# Altium schematic sheet bph.SchDoc
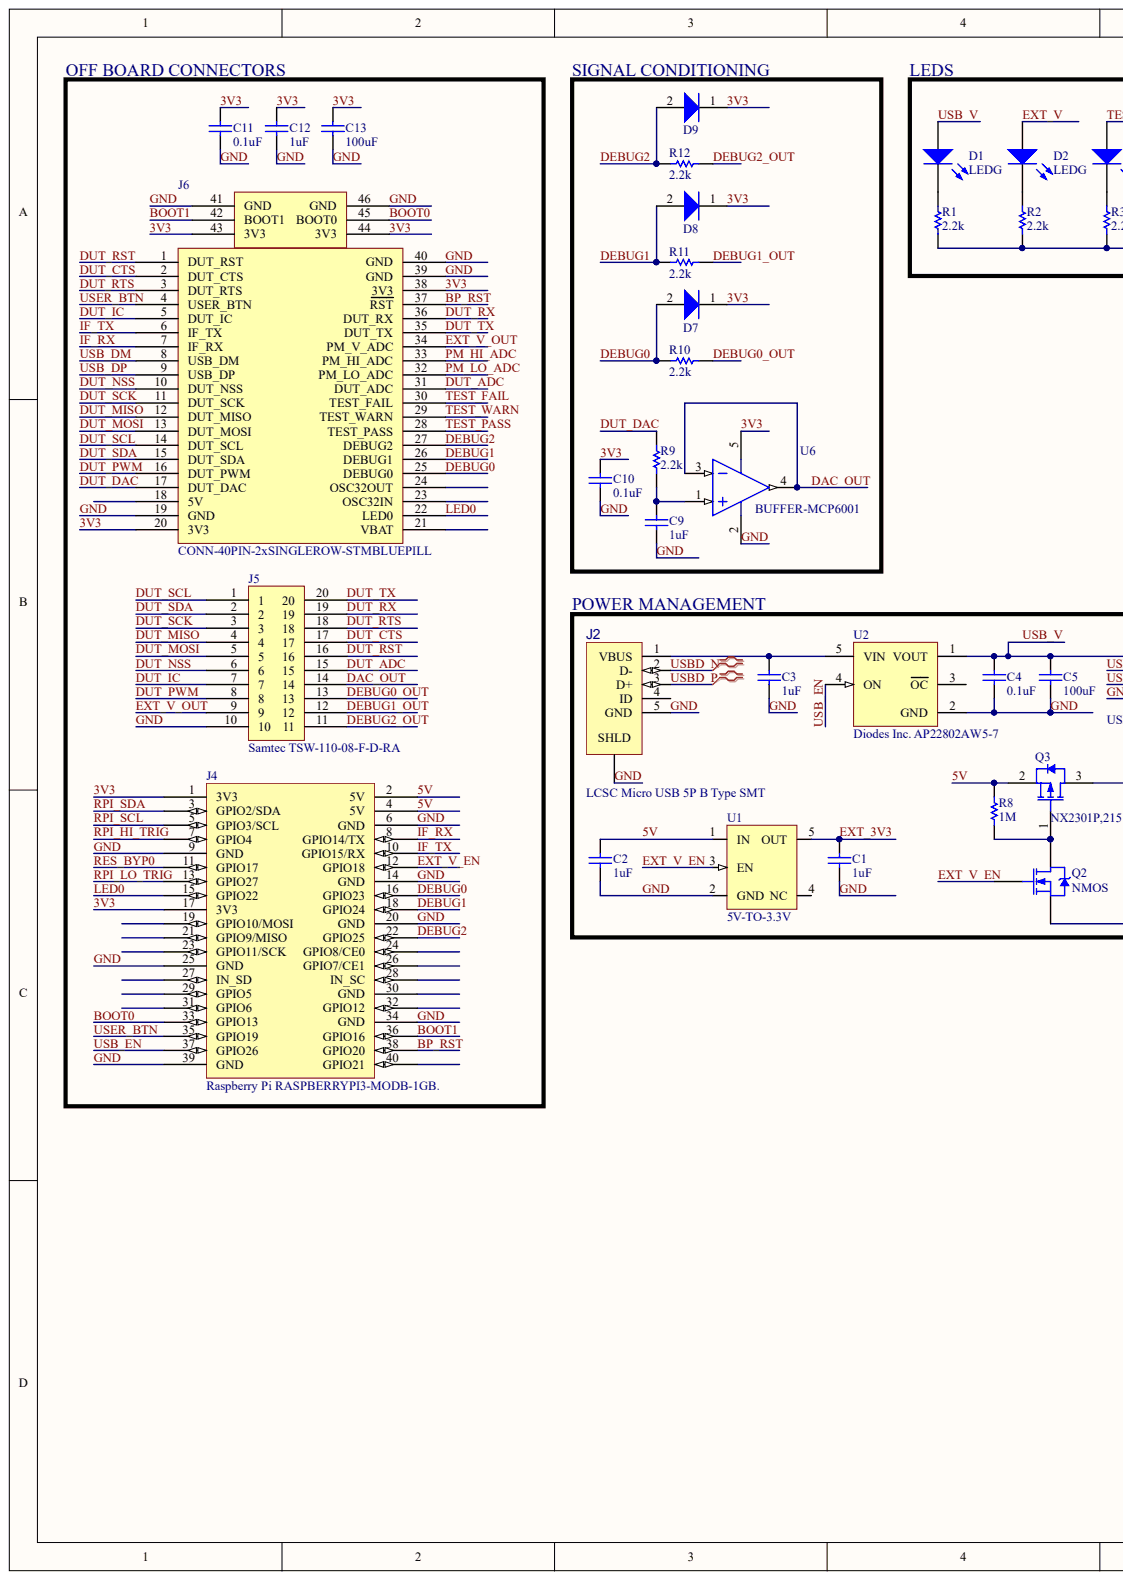
[diagram p0: bph.SchDoc, left]
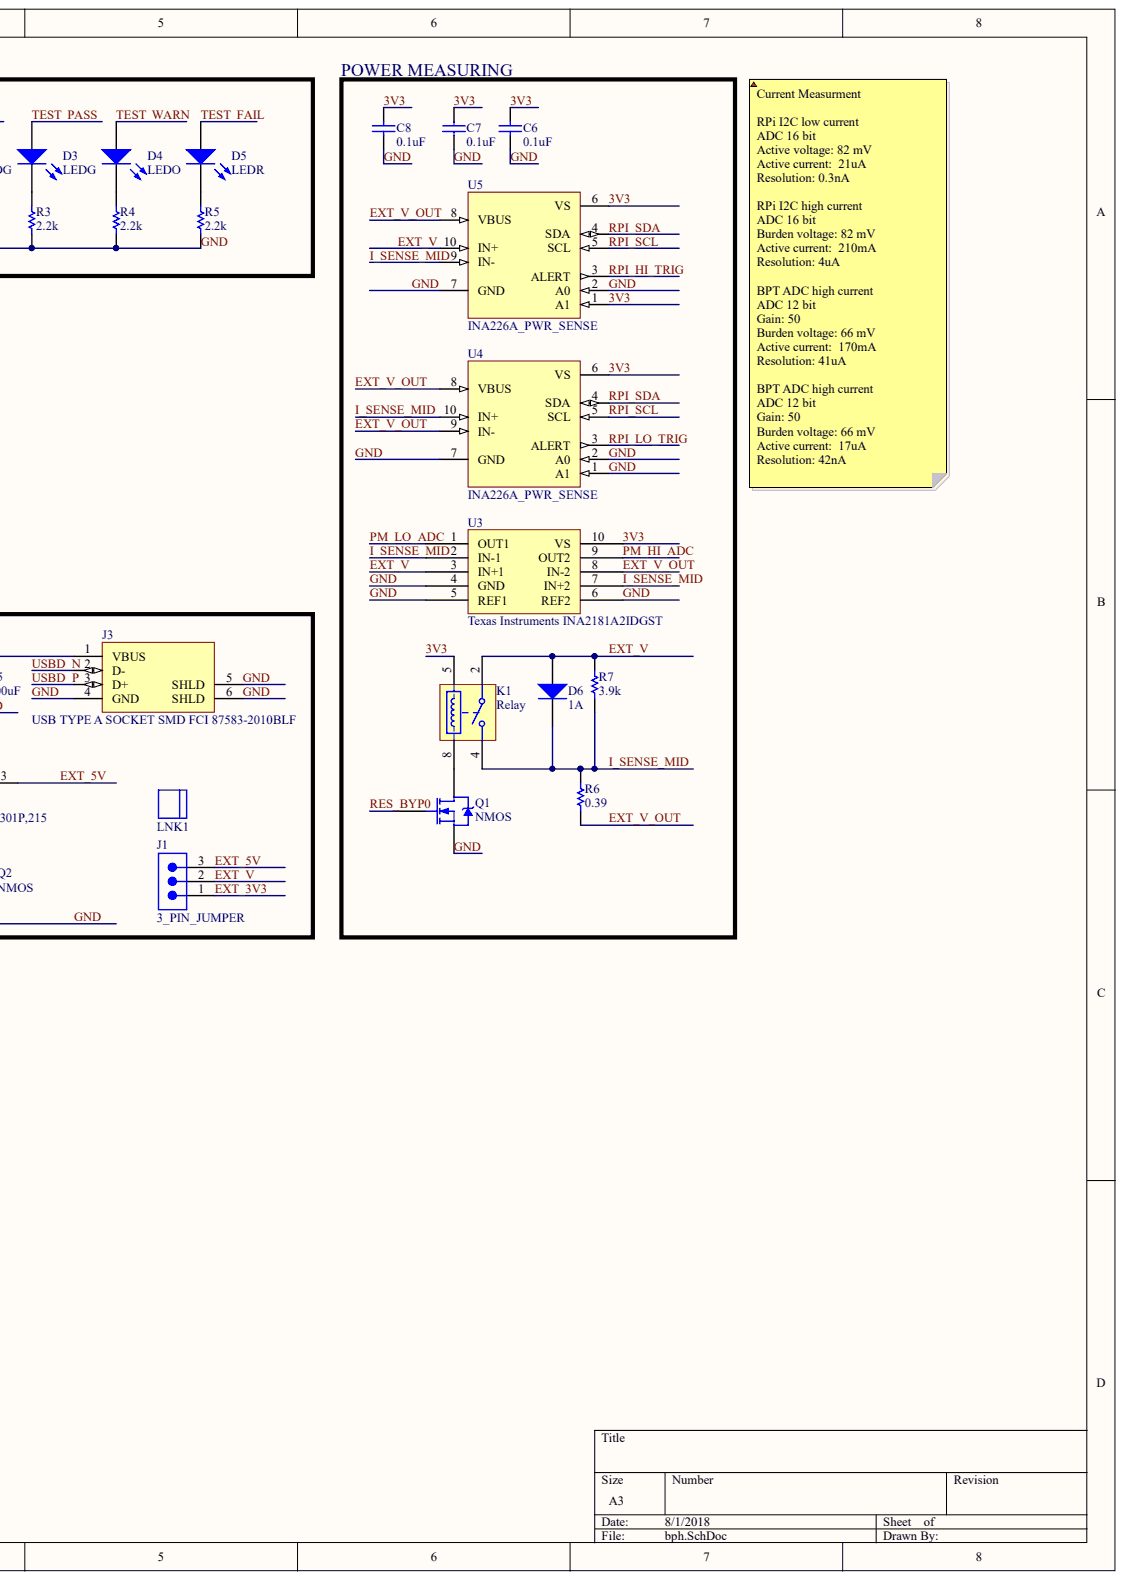
[diagram p1: bph.SchDoc, right]

## Parts
C1  1uF  [CL10B105MO8NNWC]
C2  1uF  [CL10B105MO8NNWC]
C3  1uF  [CL10B105MO8NNWC]
C4  0.1uF  [PCB-vacqisieyscyt9e4k3s1-1]
C5  100uF  [CAPC3225X280X60ML40T30]
C6  0.1uF  [PCB-vacqisieyscyt9e4k3s1-1]
C7  0.1uF  [PCB-vacqisieyscyt9e4k3s1-1]
C8  0.1uF  [PCB-vacqisieyscyt9e4k3s1-1]
C9  1uF  [CL10B105MO8NNWC]
C10  0.1uF  [PCB-vacqisieyscyt9e4k3s1-1]
C11  0.1uF  [PCB-vacqisieyscyt9e4k3s1-1]
C12  1uF  [CL10B105MO8NNWC]
C13  100uF  [CAPC3225X280X60ML40T30]
D1  LEDG  [DIOM1608X06N]
D2  LEDG  [DIOM1608X06N]
D3  LEDG  [DIOM1608X06N]
D4  LEDO  [PCB-myqng3jjgetfjfhlr5is-1]
D5  LEDR  [DIOM1608X06N]
D6  1A  [DO-214AA]
D7  NSR0140P2T5G  [ONSC-SOD-923-2-514AA-01_D_V]  (value not drawn)
D8  NSR0140P2T5G  [ONSC-SOD-923-2-514AA-01_D_V]  (value not drawn)
D9  NSR0140P2T5G  [ONSC-SOD-923-2-514AA-01_D_V]  (value not drawn)
J1  3_PIN_JUMPER  [PCB-tlokwgpvaxmsx1zr2ln6-1]
J2  LCSC Micro USB 5P B Type SMT  [PCB-edlm2od7brxgpj78b9fm-1]
J3  USB TYPE A SOCKET SMD FCI 87583-2010BLF  Manufacturer Part Number: 87583-2010BLF  [PCB-777755-1]
J4  Raspberry Pi RASPBERRYPI3-MODB-1GB.  [PCB-azd924abkl4b1ygn5hl9-1]
J5  Samtec TSW-110-08-F-D-RA  Manufacturer Part Number: TSW-110-08-F-D-RA  [DIP20]
J6  CONN-40PIN-2xSINGLEROW-STMBLUEPILL  [BLUEPILLHEADER]
K1  Relay  [PCB-q1rlmdefxni9l25t7dtb-1]
LNK1  Sullins QPC02SXGN-RC  (value not drawn)  (mechanical)
Q1  NMOS  [PCB-nw73lpgy3xhhfuwv1f85-1]
Q2  NMOS  [PCB-nw73lpgy3xhhfuwv1f85-1]
Q3  NX2301P,215  [NXP-SOT23_N]
R1  2.2k  [RESC1608X55X30LL15T15]
R2  2.2k  [RESC1608X55X30LL15T15]
R3  2.2k  [RESC1608X55X30LL15T15]
R4  2.2k  [RESC1608X55X30LL15T15]
R5  2.2k  [RESC1608X55X30LL15T15]
R6  0.39  [RESC3126X65X50ML10T20]
R7  3.9k  [RESC3225X70X50NL20T20]
R8  1M  [RESC1608X55X30LL15T15]
R9  2.2k  [RESC1608X55X30LL15T15]
R10  2.2k  [RESC1608X55X30LL15T15]
R11  2.2k  [RESC1608X55X30LL15T15]
R12  2.2k  [RESC1608X55X30LL15T15]
U1  5V-TO-3.3V  [SOT23-5_D]
U2  Diodes Inc. AP22802AW5-7  Manufacturer Part Number: AP22802AW5-7  [SOT-23-5]
U3  Texas Instruments INA2181A2IDGST  Manufacturer Part Number: INA2181A2IDGST  [SOP10]
U4  INA226A_PWR_SENSE  [PCB-TSSOP10-BoxOverlay]
U5  INA226A_PWR_SENSE  [PCB-TSSOP10-BoxOverlay]
U6  BUFFER-MCP6001  [SOT-23-OT5_N]

## Nets
3V3: C6.2 C7.2 C8.2 C10.2 C11.2 C12.2 C13.2 D7.1 D8.1 D9.1 J4.1(3V3) J4.17(3V3) J6.20(3V3) J6.38(3V3) J6.43(3V3) J6.44(3V3) K1.5 U3.10(VS) U4.6(VS) U5.1(A1) U5.6(VS) U6.5
5V: C2.2 J4.2(5V) J4.4(5V) Q3.2 R8.2 U1.1(IN)
BOOT0: J4.33(GPIO13) J6.45(BOOT0)
BOOT1: J4.36(GPIO16) J6.42(BOOT1)
BP_RST: J4.38(GPIO20) J6.37(RST)
DAC_OUT: J5.14 U6.3 U6.4
DEBUG0: D7.2 J4.16(GPIO23) J6.25(DEBUG0) R10.2
DEBUG0_OUT: J5.13 R10.1
DEBUG1: D8.2 J4.18(GPIO24) J6.26(DEBUG1) R11.2
DEBUG1_OUT: J5.12 R11.1
DEBUG2: D9.2 J4.22(GPIO25) J6.27(DEBUG2) R12.2
DEBUG2_OUT: J5.11 R12.1
DUT_ADC: J5.15 J6.31(DUT_ADC)
DUT_CTS: J5.17 J6.2(DUT_CTS)
DUT_DAC: J6.17(DUT_DAC) R9.2
DUT_IC: J5.7 J6.5(DUT_IC)
DUT_MISO: J5.4 J6.12(DUT_MISO)
DUT_MOSI: J5.5 J6.13(DUT_MOSI)
DUT_NSS: J5.6 J6.10(DUT_NSS)
DUT_PWM: J5.8 J6.16(DUT_PWM)
DUT_RST: J5.16 J6.1(DUT_RST)
DUT_RTS: J5.18 J6.3(DUT_RTS)
DUT_RX: J5.19 J6.36(DUT_RX)
DUT_SCK: J5.3 J6.11(DUT_SCK)
DUT_SCL: J5.1 J6.14(DUT_SCL)
DUT_SDA: J5.2 J6.15(DUT_SDA)
DUT_TX: J5.20 J6.35(DUT_TX)
EXT_3V3: C1.2 J1.1 U1.5(OUT)
EXT_5V: J1.3 Q3.3
EXT_V: D2.A D6.2 J1.2 K1.2 R7.2 U3.3(IN+1) U5.10(IN+)
EXT_V_EN: J4.12(GPIO18) Q2.1 U1.3(EN)
EXT_V_OUT: J5.9 J6.34(PM_V_ADC) R6.1 U3.8(IN-2) U4.8(VBUS) U4.9(IN-) U5.8(VBUS)
GND: C1.1 C2.1 C3.1 C4.1 C5.1 C6.1 C7.1 C8.1 C9.1 C10.1 C11.1 C12.1 C13.1 J2.5(GND) J2.SHLD J3.4(GND) J3.5(SHLD) J3.6(SHLD) J4.6(GND) J4.9(GND) J4.14(GND) J4.20(GND) J4.25(GND) J4.34(GND) J4.39(GND) J5.10 J6.19(GND) J6.39(GND) J6.40(GND) J6.41(GND) J6.46(GND) Q1.2 Q2.2 R1.1 R2.1 R3.1 R4.1 R5.1 U1.2(GND) U2.2(GND) U3.4(GND) U3.5(REF1) U3.6(REF2) U4.1(A1) U4.2(A0) U4.7(GND) U5.2(A0) U5.7(GND) U6.2
I_SENSE_MID: D6.1 K1.4 R6.2 R7.1 U3.2(IN-1) U3.7(IN+2) U4.10(IN+) U5.9(IN-)
IF_RX: J4.8(GPIO14/TX) J6.7(IF_RX)
IF_TX: J4.10(GPIO15/RX) J6.6(IF_TX)
LED0: J4.15(GPIO22) J6.22(LED0)
PM_HI_ADC: J6.33(PM_HI_ADC) U3.9(OUT2)
PM_LO_ADC: J6.32(PM_LO_ADC) U3.1(OUT1)
RES_BYP0: J4.11(GPIO17) Q1.1
RPI_HI_TRIG: J4.7(GPIO4) U5.3(ALERT)
RPI_LO_TRIG: J4.13(GPIO27) U4.3(ALERT)
RPI_SCL: J4.5(GPIO3/SCL) U4.5(SCL) U5.5(SCL)
RPI_SDA: J4.3(GPIO2/SDA) U4.4(SDA) U5.4(SDA)
TEST_FAIL: D5.A J6.30(TEST_FAIL)
TEST_PASS: D3.A J6.28(TEST_PASS)
TEST_WARN: D4.1 J6.29(TEST_WARN)
USB_DM: J6.8(USB_DM)
USB_DP: J6.9(USB_DP)
USB_EN: J4.37(GPIO26) U2.4(ON)
USB_V: C4.2 C5.2 D1.A J3.1(VBUS) U2.1(VOUT)
USBD_N: J2.2(D-) J3.2(D-)
USBD_P: J2.3(D+) J3.3(D+)
USER_BTN: J4.35(GPIO19) J6.4(USER_BTN)
NetC3_2: C3.2 J2.1(VBUS) U2.5(VIN)
NetC9_2: C9.2 R9.1 U6.1
NetD1_K: D1.K R1.2
NetD2_K: D2.K R2.2
NetD3_K: D3.K R3.2
NetD4_2: D4.2 R4.2
NetD5_K: D5.K R5.2
NetK1_8: K1.8 Q1.3
NetQ2_3: Q2.3 Q3.1 R8.1

Not connected: J2 4(ID); J4 19(GPIO10/MOSI) 21(GPIO9/MISO) 23(GPIO11/SCK) 24(GPIO8/CE0) 26(GPIO7/CE1) 27(IN_SD) 28(IN_SC) 29(GPIO5) 30(GND) 31(GPIO6) 32(GPIO12) 40(GPIO21); J6 18(5V) 21(VBAT) 23(OSC32IN) 24(OSC32OUT); U1 4(NC); U2 3(OC)

## Library data of the parts
C1-C3 C9 C12 = CMP-8faa45df076d506d-1: CL10 Series 0603 16 V 1 uF ±20% Tolerance X7R Multilayer Ceramic Capacitor; Lead-Free Status: Lead Free; Component Kind: Standard; Library Name: CommunityVault; OctopartID: 8faa45df076d506d; Octopart URL: http://octopart.com/cl10b105mo8nnwc-samsung-58699169; RoHS: Compliant
C4 C6-C8 C10 C11 = CMP-c41a0d2b65641bdd-2: CAP CER 0.1UF 25V X7R 0603; Manufacturer: Samsung Electro-Mechanics America, Inc; Manufacturer Part Number: CL10B104KA8NNNC; Capacitance Tolerance: ±10%; Lead-Free Status: Lead Free; Component Kind: Standard; Dielectric Characteristic: X7R; Library Name: CommunityVault; Mounting Style: Surface Mount; Octopart URL: http://octopart.com/cl10b104ka8nnnc-samsung-3900440
C5 C13 = CMP-9010136-2: Samsung Electro-Mechanics 100F 20% 10 V X5R Dielectric SMD Ceramic Multilayer Capacitor 1210; Manufacturer Part Number: CL32A107MPVNNNE; Capacitance: 0.0001 F; Lead-Free Status: Lead Free; Voltage Rating (DC): 10.0 V; Capacitance Tolerance: ±20%; Case/Package: 1210; Component Kind: Standard; Dielectric Characteristic: X5R; Library Name: CommunityVault; Mounting Style: Surface Mount; OctopartID: 639fabe94114bc58; Octopart Url: https://octopart.com/cl32a107mpvnnne-samsung-24905709; RoHS: Compliant
D1-D3 = CMP-1544849-7: LED; SMD; 0603; green; 18-71mcd; 1.6x0.8x0.55mm; 130°; 1.9÷2.4V; Case/Package (SI): 1608; Forward Voltage: 2.00 V; Lead-Free Status: Lead Free; Lens Type: Non-Diffused; Luminous Intensity: 35.0 mcd; Wavelength: 574 nm; Case/Package: 0603; Component Kind: Standard; Library Name: CommunityVault; OctopartID: 0b34c2364a41d4f3; Octopart URL: https://octopart.com/ltst-c191kgkt-lite-on-549907; Packaging: Tape & Reel (TR), Cut Tape (CT); RoHS: Compliant; Size-Height: 550 µm; Size-Length: 1.60 mm; Size-Width: 800 µm
D4 = CMP-f8913193b9e52756-2: LED; SMD; 0603; orange; 45-90mcd; 1.6x0.8x0.55mm; 130°; 2÷2.4V; 20mA; Manufacturer Part Number: LTST-C191KFKT; Case/Package (SI): 1608; Color: Red, Orange; Forward Voltage: 2.00 V; Lead-Free Status: Lead Free; Lens Color: Transparent; Case/Package: 0603; Component Kind: Standard; Library Name: CommunityVault; OctopartID: f8913193b9e52756; Size-Height: 550 µm; Size-Length: 1.60 mm; Viewing Angle: 130 °
D5 = CMP-1544848-9: LED; SMD; 0603; red; 18-54mcd; 1.6x0.8x0.55mm; 130°; 2÷2.4V; 20mA; Case/Package (SI): 1608; Lead-Free Status: Lead Free; Luminous Intensity: 54.0 mcd; Wavelength: 639 nm; Color: Red; Component Kind: Standard; Library Name: CommunityVault; Mounting Style: Surface Mount, Vertical; Operating Temperature: 85.0 °C (max); Packaging: Tape & Reel (TR), Cut Tape (CT); RoHS: Compliant; Size-Height: 550 µm; Viewing Angle: 130 °
D6 = CMP-6ba5a3a1f32cb462-2: Diode Schottky 15V 1A 2-Pin DO-214AB T/R; Manufacturer Part Number: LSM115JE3/TR13; Component Kind: Standard; Library Name: CommunityVault; OctopartID: 6ba5a3a1f32cb462; RoHS: Compliant
D7-D9 = CMP-4964315-2: Schottky Barrier Diode, 2-Pin SOD-923, Pb-Free, Tape and Reel; Forward Voltage: 0.35 V; Lead-Free Status: Lead Free; Component Kind: Standard; Library Name: CommunityVault; Lifecycle Status: Active; Manufacturer Part Number: NSR0140P2T5G; Mounting Style: Surface Mount; Number of Pins: 2; OctopartID: 49a880d0e42a966f; Octopart Url: https://octopart.com/nsr0140p2t5g-on+semiconductor-7506148; Packaging: Cut Tape (CT); REACH SVHC Compliance: No SVHC; RoHS: Compliant
J1 = CMP-aab0639043747577-2: Conn Unshrouded Header HDR 3 POS 2.54mm Solder ST SMD Automotive Bulk; Component Kind: Standard; Contact Material: Phosphor Bronze; Library Name: CommunityVault; Octopart URL: https://octopart.com/tsm-103-01-l-sv-samtec-2804898
J2 = CMP-2509ed477c75face-9: Single Port 5 Contact Horizontal Micro B USB 2.0 Connector; Component Kind: Standard; Library Name: CommunityVault
J3 = CMP-777755-8: CONN RCPT USB 4POS UNIV SERIAL; Contact Resistance: 0.03 Ω; Current Rating: 1.0 A; Gender: Female; Insulation Resistance: 1000000000.0 Ω; Number of Contacts: 4; Number of Mating Cycles: 1500; Component Kind: Standard; Contact Material: Copper Alloy; Contact Plating: Gold; Flammability Rating: UL 94 V-0; Library Name: CommunityVault; Material: Tin; Mounting Style: Surface Mount; OctopartID: 38f1f3924656e736; Octopart Url: https://octopart.com/87583-2010blf-fci-40459201; Orientation: Right Angle; REACH SVHC Compliance: No SVHC; RoHS: Compliant
J4 = CMP-ee2e2367d44431e9-24: Raspberry Pi 3 Model B, 64bit, 1GB RAM, Wi-Fi 802.11n BT 4.1; Manufacturer Part Number: BCS-120-L-D-TE; Component Kind: Standard; Library Name: CommunityVault; OctopartID: ee2e2367d44431e9; Octopart URL: https://octopart.com/bcs-120-l-d-te-samtec-291872?r=sp&s=JT3TK7s2TkuosAS8rUO4oA; RoHS: Compliant
J5 = CMP-781fb00d073da7d8-1: Conn Unshrouded Header HDR 20 POS 2.54mm Solder RA Thru-Hole Bulk; Gender: Male; Number of Contacts: 20; Component Kind: Standard; Contact Material: Phosphor Bronze; Contact Plating: Gold; Library Name: CommunityVault; Mounting Style: Through Hole; Number of Rows: 2; OctopartID: 781fb00d073da7d8; Octopart URL: https://octopart.com/tsw-110-08-f-d-ra-samtec-18373188; Pin Pitch: 2.54 mm; REACH SVHC Compliance: No SVHC; RoHS: Compliant
J6 = CMP-jycs8hbplhxku1l5p8l5-5: 40 pin total, 2 single 20 pin for the stm blue pill; Component Kind: Standard; Library Name: CommunityVault
K1 = CMP-2df4e489ad28f233-3: Relay; E-Mech; Communication; SPST-NO; Cur-Rtg 0.3/1AAC/ADC; Ctrl-V 3DC; SMD Mnt; SMT; Manufacturer: Omron; Manufacturer Part Number: G6L-1F-DC3; Component Kind: Standard; Library Name: FavoritesLibrary; Octopart Url: https://octopart.com/g6l-1f-dc3-omron-67913; Seller Landing  Url: https://octopart.com/g6l-1f-dc3-omron-67913
LNK1 = CMP-7bbbb39e0d56a47c-1: 2 Position 2.54 mm Centerline Single Circuit Open Top Jumper; Gender: Female; Lead-Free Status: Lead Free; Library Name: CommunityVault; Manufacturer Part Number: QPC02SXGN-RC; OctopartID: 7bbbb39e0d56a47c; Octopart URL: http://octopart.com/qpc02sxgn-rc-sullins-19878795; Packaging: Bulk; RoHS: Compliant
Q1 Q2 = CMP-b9a271de0f056665-4: Trans MOSFET N-CH 60V 0.31A Automotive 3-Pin SOT-23 T/R; Rds On (Max) @ Id, Vgs: 3 Ohm @ 115mA, 10V; Component Kind: Standard; Library Name: CommunityVault; Lifecycle Status: Active; OctopartID: b9a271de0f056665; Octopart URL: http://octopart.com/dmn65d8l-7-diodes+inc.-24548289; REACH SVHC Compliance: No SVHC
Q3 = CMP-836208-2: P-Channel Trench MOSFET, -20 V, -2 A, -55 to 150 degC, 3-Pin SOT23, Tape and Reel; Lead-Free Status: Lead Free; Component Kind: Standard; Library Name: CommunityVault; Lifecycle Status: Active; Manufacturer Part Number: NX2301P,215; OctopartID: 847e4c5a89ec5bb9; Octopart Url: https://octopart.com/nx2301p%2C215-nxp+semiconductors-19802356; Polarity: P-Channel; RoHS: Compliant
R1-R5 R9-R12 = CMP-3652689-2: Thick Film Resistors - SMD 0603 2.2Kohms 5% Tol; Manufacturer Part Number: ERJ-3GEYJ222V; Lead-Free Status: Lead Free; Power Rating: 0.1 W; Resistance: 2200.0 Ω; Voltage Rating (DC): 75.0 V; Case/Package: 0603; Component Kind: Standard; Library Name: CommunityVault; Lifecycle Status: Not Listed by Manufacturer; Mounting Style: Surface Mount; OctopartID: 3907f340b037e6c0; Octopart Url: https://octopart.com/erj-3geyj222v-panasonic-55422038; Packaging: Cut Tape (CT); REACH SVHC Compliance: No SVHC; Resistance Tolerance: ±5%; RoHS: Compliant; Size-Height: 0.00045 m; Size-Length: 0.0016 m; Size-Width: 0.0008 m
R6 = CMP-8887804-2: Current Sense Resistors - SMD 0.39 Ohm 1% 1/2W; Manufacturer Part Number: RL1210FR-070R39L; Resistance: 0.39 Ω; Case/Package: 1210; Component Kind: Standard; Library Name: CommunityVault; Mounting Style: Surface Mount; OctopartID: 5d819510db858246; Octopart Url: https://octopart.com/rl1210fr-070r39l-yageo-26977122; Resistance Tolerance: ±1%
R7 = CMP-3672539-2: Thick Film Resistors - SMD 1210 3.9Kohms 1% Tol; Manufacturer Part Number: ERJ-14NF3901U; Lead-Free Status: Lead Free; Power Rating: 0.5 W; Resistance: 3900.0 Ω; Voltage Rating (DC): 200.0 V; Case/Package: 1210; Component Kind: Standard; Library Name: CommunityVault; Lifecycle Status: Not Listed by Manufacturer; Mounting Style: Surface Mount; OctopartID: 96e05ca4369e4927; Octopart Url: https://octopart.com/erj-14nf3901u-panasonic-55477528; Packaging: Tape; REACH SVHC Compliance: No SVHC; Resistance Tolerance: ±1%; RoHS: Compliant; Size-Height: 0.0006 m; Size-Length: 0.0032 m; Size-Width: 0.0025 m
R8 = CMP-3656597-2: Thick Film Resistors - SMD 0603 1Mohms 5% Tol; Manufacturer Part Number: ERJ-3GEYJ105V; Lead-Free Status: Lead Free; Power Rating: 0.1 W; Resistance: 1000000.0 Ω; Voltage Rating (DC): 75.0 V; Case/Package: 0603; Component Kind: Standard; Library Name: CommunityVault; Lifecycle Status: Not Listed by Manufacturer; Mounting Style: Surface Mount; OctopartID: 962a5c6d1f5449ed; Octopart Url: https://octopart.com/erj-3geyj105v-panasonic-55421976; Packaging: Cut Tape (CT); REACH SVHC Compliance: No SVHC; Resistance Tolerance: ±5%; RoHS: Compliant; Size-Height: 0.00045 m; Size-Length: 0.0016 m; Size-Width: 0.0008 m
U1 = CMP-15095236-4: AP2112 Series 0.6 A 3.3 V Fixed Output SMT LDO Linear Regulator - SOT23-5; Manufacturer Part Number: AP2112K-3.3TRG1; Lead-Free Status: Lead Free; Component Kind: Standard; Library Name: CommunityVault; Lifecycle Status: Not Listed by Manufacturer; OctopartID: 0ec2c6107f99d105; Octopart Url: http://octopart.com/ap2112k-3.3trg1-diodes+inc.-30954557; RoHS: Compliant; Seller Landing  Url: https://octopart-clicks.com/click/track?country=US&ak=8157f166&sig=01867c6&sid=459&ppid=30954557&vpid=95776131&ct=offers
U2 = CMP-dedb15079114011c-2: AP22802 Series 5.5 V 50 mO Single Channel High Side Power Switch - SOT-25; Lead-Free Status: Lead Free; Component Kind: Standard; Library Name: FavoritesLibrary; Lifecycle Status: Not Listed by Manufacturer; OctopartID: dedb15079114011c; Octopart URL: http://octopart.com/ap22802aw5-7-diodes+inc.-47606441; RoHS: Compliant
U3 = CMP-6521a912c7adbae6-3: SP Amp Current Sense Amp Dual R-R O/P 5.5V 10-Pin VSSOP T/R; Case/Package: VSSOP; Component Kind: Standard; Library Name: CommunityVault; Number of Pins: 10; Octopart URL: https://octopart.com/ina2181a2idgst-texas+instruments-87656426
U4 U5 = CMP-947c5c4360184bbb-13: 36-V, Bi-Directional, Ultra-High Accuracy, Low-/High-Side, I2C Out Current/Power Monitor w/ Alert 10-VSSOP -40 to 125; Component Kind: Standard; Library Name: CommunityVault
U6 = CMP-1319527-4: 1 MHz, Low-Power Operational Amplifier, 5-Pin SOT-23, Industrial Temperature, Tape and Reel; Manufacturer Part Number: MCP6001UT-I/OT; Bandwidth: 1000000.0 Hz; Input Offset Drift: 2e-06 V/K; Lead-Free Status: Lead Free; Number of Channels: 1; Slew Rate: 0.6 V/μs; Case/Package: SOT-23; Component Kind: Standard; Gain Bandwidth Product: 1000000.0 Hz; Library Name: CommunityVault; Lifecycle Status: Active; Mounting Style: Surface Mount; Number of Circuits: 1; Number of Pins: 5; OctopartID: 592c9020195386eb; Octopart Url: https://octopart.com/mcp6001ut-i%2Fot-microchip-419097; REACH SVHC Compliance: No SVHC; RoHS: Compliant
